# Altium schematic sheet Sheet7.SchDoc(Sheet7)
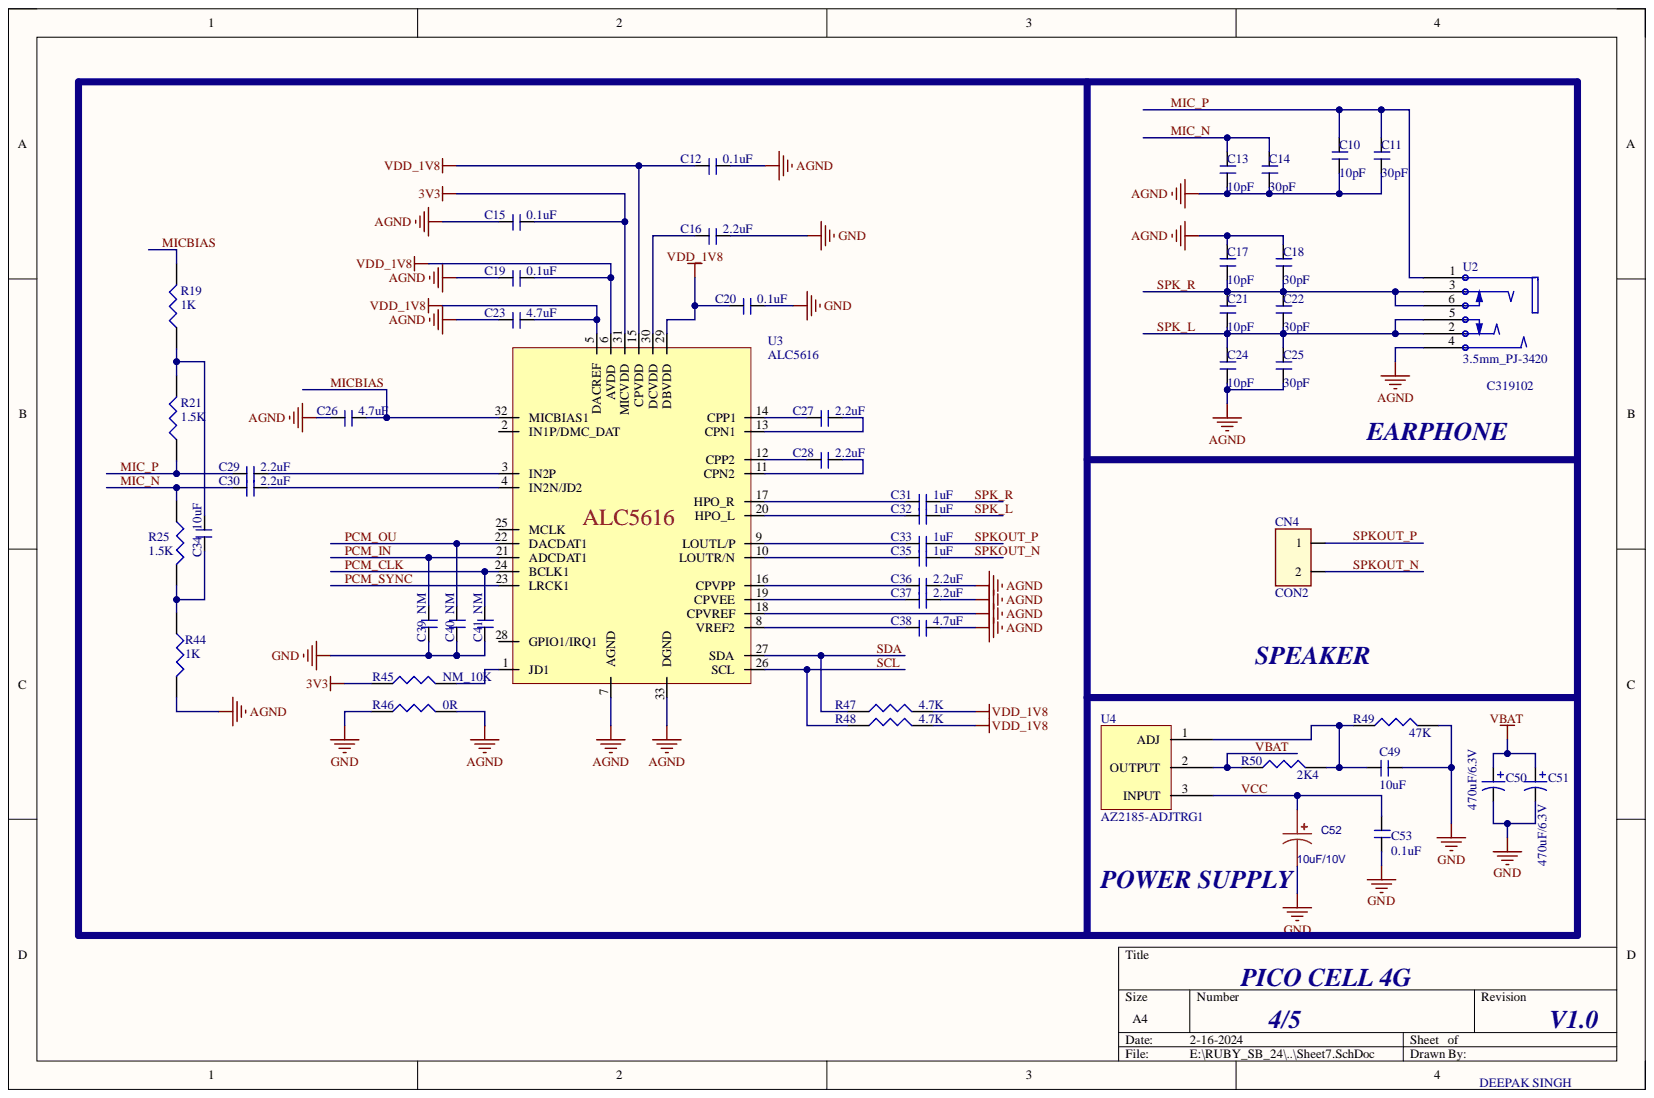
[diagram p0: Sheet7.SchDoc]

## Parts
C10  10pF  [C_0603]
C11  30pF  [C_0603]
C12  0.1uF  [C_0603]
C13  10pF  [C_0603]
C14  30pF  [C_0603]
C15  0.1uF  [C_0603]
C16  2.2uF  [C_0603]
C17  10pF  [C_0603]
C18  30pF  [C_0603]
C19  0.1uF  [C_0603]
C20  0.1uF  [C_0603]
C21  10pF  [C_0603]
C22  30pF  [C_0603]
C23  4.7uF  [C_0603]
C24  10pF  [C_0603]
C25  30pF  [C_0603]
C26  4.7uF  [C_0603]
C27  2.2uF  [C_0603]
C28  2.2uF  [C_0603]
C29  2.2uF  [C_0603]
C30  2.2uF  [C_0603]
C31  1uF  [C_0603]
C32  1uF  [C_0603]
C33  1uF  [C_0603]
C34  10uF  [C_0603]
C35  1uF  [C_0603]
C36  2.2uF  [C_0603]
C37  2.2uF  [C_0603]
C38  4.7uF  [C_0603]
C39  NM  [C_0603]
C40  NM  [C_0603]
C41  NM  [C_0603]
C49  10uF  [C_0603]
C50  470uF/6.3V  [CASE-D_7343]
C51  470uF/6.3V  [CASE-D_7343]
C52  10uF/10V  [Tantlum_A_3216]
C53  0.1uF  [C_0603]
CN4  CON2  [2P_2.54MM]
R19  1K  [R_0603]
R21  1.5K  [R_0603]
R25  1.5K  [R_0603]
R44  1K  [R_0603]
R45  NM_10K  [R_0603]
R46  0R  [R_0603]
R47  4.7K  [R_0603]
R48  4.7K  [R_0603]
R49  47K  [R_0603]
R50  2K4  [R_0603]
U2  3.5mm_PJ-3420  [3.5mm_PJ-3420]
U3  ALC5616  [QFN-32]
U4  AZ2185-ADJTRG1  [TO-252-3]

## Nets
3V3: C15.2 R45.1 U3.31(MICVDD)
AGND: C10.1 C11.1 C12.2 C13.1 C14.1 C15.1 C17.2 C18.2 C19.1 C23.1 C24.1 C25.1 C26.1 C36.2 C37.2 C38.2 R44.2 R46.2 U2.4 U3.7(AGND) U3.18(CPVREF) U3.33(DGND)
GND: C16.2 C20.2 C39.1 C40.1 C41.1 C49.2 C50.K C51.K C52.2 C53.1 R46.1 R49.2
VDD_1V8: C12.1 C19.2 C20.1 C23.2 R47.2 R48.2 U3.5(DACREF) U3.6(AVDD) U3.15(CPVDD) U3.29(DBVDD)
MIC_N: C13.2 C14.2 C30.1 R25.1
MIC_P: C10.2 C11.2 C29.1 R21.1 U2.1
MICBIAS: C26.2 R19.2 U3.32(MICBIAS1)
PCM_CLK: C41.2 U3.24(BCLK1)
PCM_IN: C39.2 U3.21(ADCDAT1)
PCM_OU: C40.2 U3.22(DACDAT1)
PCM_SYNC: U3.23(LRCK1)
SCL: R48.1 U3.26(SCL)
SDA: R47.1 U3.27(SDA)
SPK_L: C21.1 C22.1 C24.2 C25.2 C32.2 U2.2 U2.5
SPK_R: C17.1 C18.1 C21.2 C22.2 C31.2 U2.3 U2.6
SPKOUT_N: C35.2 CN4.2
SPKOUT_P: C33.2 CN4.1
VBAT: C50.A C51.A R50.1 U4.2
VCC: C52.1 C53.2 U4.3
NetC16_1: C16.1 U3.30(DCVDD)
NetC27_1: C27.1 U3.14(CPP1)
NetC27_2: C27.2 U3.13(CPN1)
NetC28_1: C28.1 U3.12(CPP2)
NetC28_2: C28.2 U3.11(CPN2)
NetC29_2: C29.2 U3.3(IN2P)
NetC30_2: C30.2 U3.4(IN2N/JD2)
NetC31_1: C31.1 U3.17(HPO_R)
NetC32_1: C32.1 U3.20(HPO_L)
NetC33_1: C33.1 U3.9(LOUTL/P)
NetC34_1: C34.1 R19.1 R21.2
NetC34_2: C34.2 R25.2 R44.1
NetC35_1: C35.1 U3.10(LOUTR/N)
NetC36_1: C36.1 U3.16(CPVPP)
NetC37_1: C37.1 U3.19(CPVEE)
NetC38_1: C38.1 U3.8(VREF2)
NetC49_1: C49.1 R49.1 R50.2 U4.1
NetR45_2: R45.2 U3.1(JD1)

Not connected: U3 2(IN1P/DMC_DAT) 25(MCLK) 28(GPIO1/IRQ1)

## Library data of the parts
C10-C41 C49 C53 = CAP_NONPOLAR: Component Kind: Standard; Library Name: dxp_pasl_lib.IntLib
C50 C51 = CAP_ELEC: Component Kind: Standard; Library Name: dxp_pasl_lib.IntLib
C52 = 270uF: BOM_Manufacturer: rubycon(红宝石); BOM_Manufacturer Part: 100ZL270MEFC12.5X35; Contributor: lcsc; nameAlias: Capacitance; BOM_JLCPCB Part Class: Extended Part; BOM_Supplier: LCSC; BOM_Supplier Part: C1598036; Component Kind: Standard; link: https://atta.szlcsc.com/upload/public/pdf/source/20210810/D32E969E718DCFB364F518B2A745C406.pdf; spicePre: C; spiceSymbolName: 100ZL270MEFC12.5X35; Library Name: MIX.SchLib
R19 R21 R25 R44-R50 = RES: Component Kind: Standard; Library Name: dxp_pasl_lib.IntLib
U2 = Headphone Holder SMD: Component Kind: Standard; Library Name: dxp_pasl_lib.IntLib
U3 = ALC5616: Ultra-Low Power Audio CODEC QFN-32 ICs ROHS #C472693; CATEGORY: AUDIO IC; Component Kind: Standard; Library Name: dxp_pasl_lib.IntLib
U4 = AZ2185-ADJTRG1: 3A LOW DROPOUT LINEAR REGULATOR TO-252-3 PACKAGE; Component Kind: Standard; Library Name: dxp_pasl_lib.IntLib
CN4 = CON2: Component Kind: Standard; Library Name: dxp_pasl_lib.IntLib
